# Altium schematic sheet Main.SchDoc
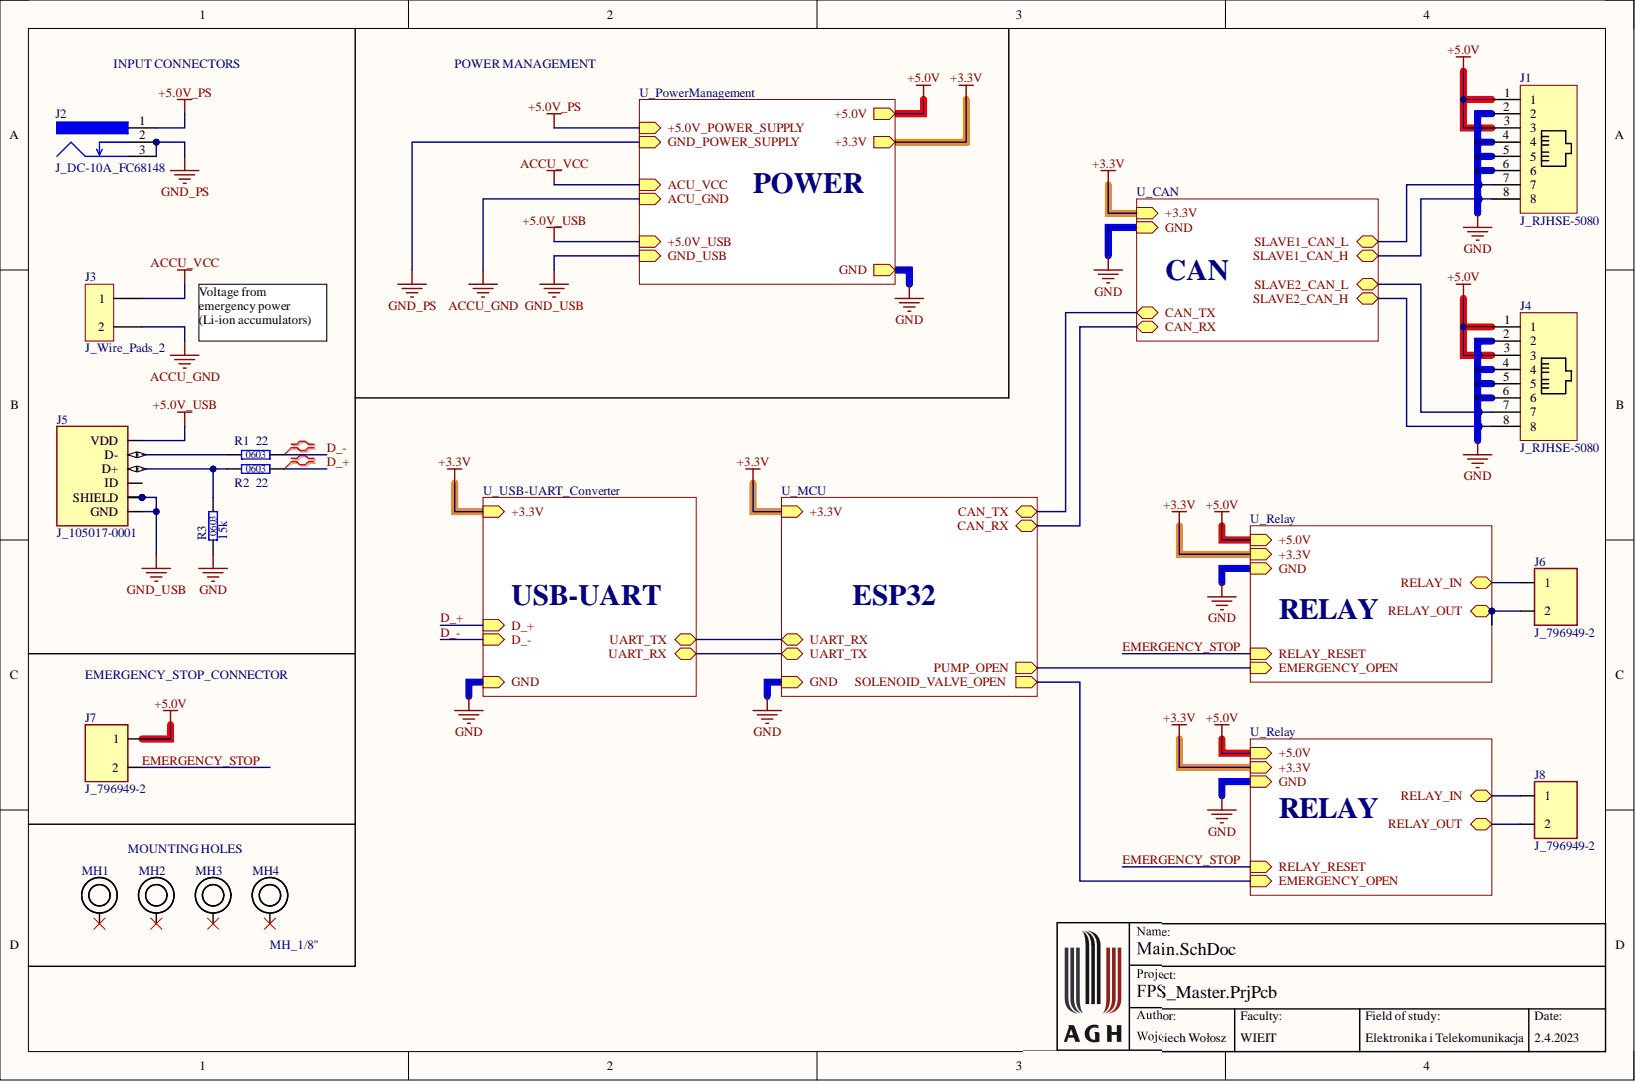
[diagram p0: Main.SchDoc]

## Parts
J1  J_RJHSE-5080  [J_RJHSE-5080]
J2  J_DC-10A_FC68148  [2_1x2_5_DC_CONN_THT]
J3  J_Wire_Pads_2  [J_Wire_Pads_2]
J4  J_RJHSE-5080  [J_RJHSE-5080]
J5  J_105017-0001  [MICROUSB_THT]
J6  J_796949-2  [TERMINAL_BLOCK_5.08_2POS]
J7  J_796949-2  [TERMINAL_BLOCK_5.08_2POS]
J8  J_796949-2  [TERMINAL_BLOCK_5.08_2POS]
MH1  MH_1/8"  [MH_1_8"]  (value not drawn)
MH2  MH_1/8"  [MH_1_8"]  (value not drawn)
MH3  MH_1/8"  [MH_1_8"]  (value not drawn)
MH4  MH_1/8"  [MH_1_8"]
R1  value 22  SCH_Footprint: 0603  [R_0603]  (hidden comment: R_22_0603_5)
R2  value 22  SCH_Footprint: 0603  [R_0603]  (hidden comment: R_22_0603_5)
R3  value 15k  Case/Package: 0603  [R_0603]  (hidden comment: R_15k_0603_5)

## Nets
+5.0V: J1.1 J1.3 J4.1 J4.3 J7.1
+5.0V_PS: J2.1
+5.0V_USB: J5.1(VDD)
ACCU_GND: J3.2
ACCU_VCC: J3.1
GND: J1.2 J1.4 J1.5 J1.6 J4.2 J4.4 J4.5 J4.6 R3.1
GND_PS: J2.2 J2.3
GND_USB: J5.5(GND) J5.P$8(SHIELD) J5.P$9 J5.P$10 J5.P$11
D_+: R2.2
D_-: R1.2
EMERGENCY_STOP: J7.2
NetJ1_7: J1.7
NetJ1_8: J1.8
NetJ4_7: J4.7
NetJ4_8: J4.8
NetJ5_2: J5.2(D-) R1.1
NetJ5_3: J5.3(D+) R2.1 R3.2
NetJ5_4: J5.4(ID)
NetJ6_1: J6.1
NetJ6_2: J6.2
NetJ8_1: J8.1
NetJ8_2: J8.2
NetMH1_1: MH1.1
NetMH2_1: MH2.1
NetMH3_1: MH3.1
NetMH4_1: MH4.1
+3.3V:
N00001:
N00002:
N00003:
N00004:
N00005:
N00006:

## Library data of the parts
J1 J4 = CMP-002-00029-5: RJ-45; Jack Modular Connector 8p8c (RJ45, Ethernet) 90° Angle (Right) Unshielded; Case/Package: THT; Component Kind: Standard; Connector Type: RJ45; Library Name: Altium Concord Pro on A365; Pins: 8
J2 = CMP-002-00026-5: Socket; DC supply; male; 5.5/2.1mm; 5.5mm; 2.1mm; soldering; 5A THT; Manuf. Part: DC-10A FC68148; Voltage Rating: 12V; Case/Package: -; Component Kind: Standard; ComponentLink1Description: Datasheet; ComponentLink1URL: https://www.tme.eu/Document/9908a311fa187cab41d52074eae7ada8/FC68148.pdf; Connector Type: DC Supply; Gender: Male; Library Name: Altium Concord Pro on A365; Max Current Rating: 5A; Mounting Technology: THT; Pins: 3; RoHS Compliant: Yes; SCH_Footprint: 2_1x2_5_DC_CONN_THT
J3 = CMP-002-00010-5: Pads used for soldering wires / measurement,; SCH_Footprint: WIREPAD_2; Component Kind: Standard; Library Name: Altium Concord Pro on A365
J5 = CMP-00027-00001-7: USB Connectors MICRO USB B RECPT BTTM MNT SMT TH TABS; Connector Type: Signal; Gender: Female; Max Current Rating: 1A; Voltage Rating: 30V; Case/Package: -; Component Kind: Standard; ComponentLink1Description: Datasheet; ComponentLink1URL: https://www.molex.com/molex/products/part-detail/io_connectors/1050170001; Library Name: Altium Concord Pro on A365; Max Operating Temperature: 85°C; Min Operating Temperature: -30°C; Mounting Technology: THT; Orientation: Right Angle; Pins: 5; RoHS Compliant: Yes; SCH_Footprint: MICROUSB_THT
J6-J8 = CMP-002-00027-3: Fixed Terminal Blocks 5.08MM VERTICAL 2P wire protector; Max Current Rating: 17.5A; Voltage Rating: 300V; Wire Gauge Max: 12 AWG; Wire Gauge Min: 30 AWG; Case/Package: -; Component Kind: Standard; Connector Type: Terminal Block; Gender: -; Library Name: Altium Concord Pro on A365; Mounting Technology: THT; Pins: 2; Pitch: 5.08mm; RoHS Compliant: Yes
MH1-MH4 = CMP-002-000009-2: 1/8"/0.22" (3.2mm x 5.5mm) Mounting hole; Hole size [inch]: 1\8"; Component Kind: Standard; Diameter [inch]: 0.22"; Diameter [mm]: 5.5mm; Hole size [mm]: 3.2mm; Library Name: Altium Concord Pro on A365
R1 R2 = CMP-009-00037-4: Tolerance: 5%; Component Kind: Standard; Description: R_22_0603_5; Library Name: Altium Concord Pro on A365; Pins: 2
R3 = CMP-009-00036-4: Thick Film Resistors - SMD 1/10watt 15Kohms 5%; Component Kind: Standard; HelpURL: https://eu.mouser.com/datasheet/2/427/dcrcwe3-1762152.pdf; Library Name: Altium Concord Pro on A365; Pins: 2; SCH_Footprint: 0603; Tolerance: 5%
